# Altium schematic sheet Stepper_Driver.SchDoc
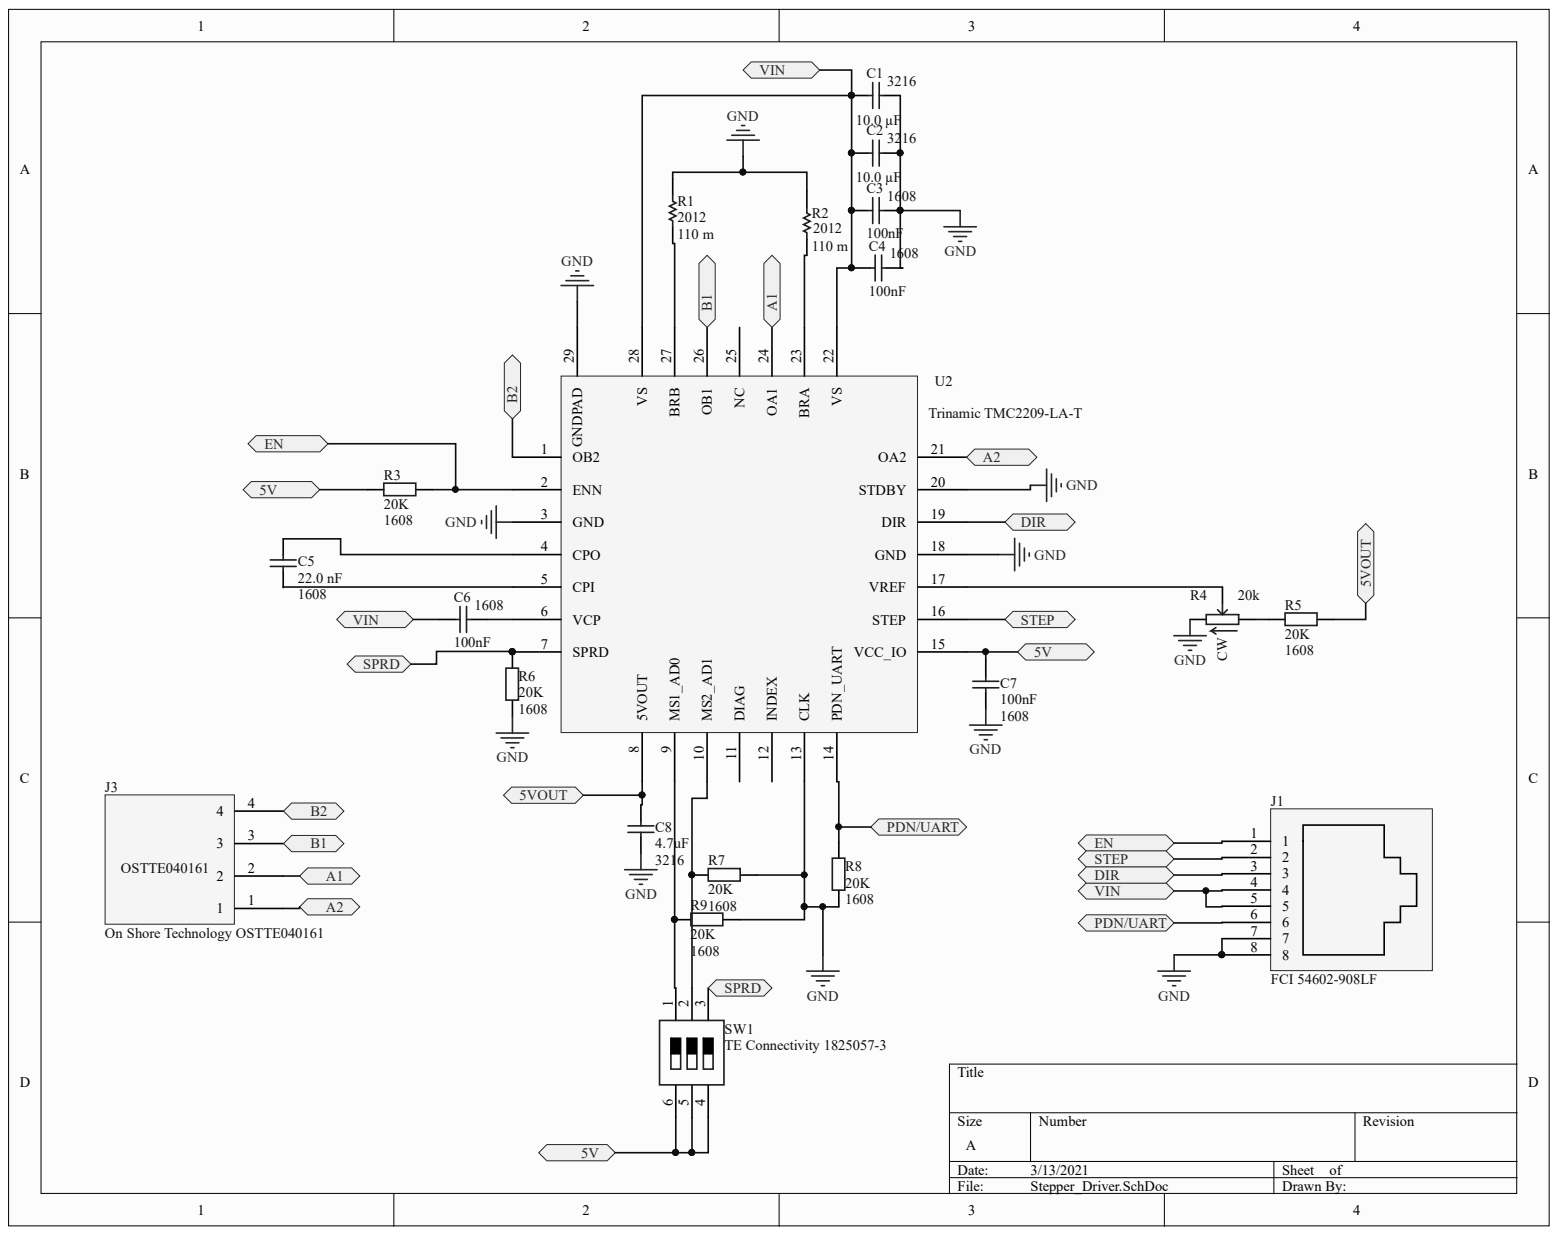
[diagram p0: Stepper_Driver.SchDoc]

## Parts
C1  Size: 3216  [High Density]  (hidden comment: Cap)
C2  Capacitance: 10.0 µF  Size: 3216  [High Density]  (hidden comment: Cap)
C3  100nF  Size: 1608  [CAPC1608X09N]
C4  100nF  Size: 1608  [CAPC1608X09N]
C5  Size: 1608  [PCB-v3fthkug2pbv1qvbzexa-1]  (hidden comment: Walsin Technologies 0603B223K500CT)
C6  100nF  Size: 1608  [CAPC1608X09N]
C7  100nF  Size: 1608  [CAPC1608X09N]
C8  4.7uF  Size: 3216  [CAPC3216X180X55ML20T25]
J1  FCI 54602-908LF  Manufacturer Part Number: 54602-908LF  [PCB-kyusfhn5h9rxu8er00w0-1]
J3  On Shore Technology OSTTE040161  [OSTTE040161]
R1  Case/Package: 2012  [RESC2012X06N]  (hidden comment: Panasonic ERJ-6DSFR11V)
R2  Case/Package: 2012  [RESC2012X06N]  (hidden comment: Panasonic ERJ-6DSFR11V)
R3  20K  Size: 1608  [PCB-1ci9j4ma2hnf2m9akcl3-1]
R4  Resistance: 20k  [PCB-aorwn4mtqwimg0tyvqvx-1]  (hidden comment: TC33X-2-203E)
R5  20K  Size: 1608  [PCB-1ci9j4ma2hnf2m9akcl3-1]
R6  20K  Size: 1608  [PCB-1ci9j4ma2hnf2m9akcl3-1]
R7  20K  [PCB-1ci9j4ma2hnf2m9akcl3-1]
R8  20K  Size: 1608  [PCB-1ci9j4ma2hnf2m9akcl3-1]
R9  20K  Size: 1608  [PCB-1ci9j4ma2hnf2m9akcl3-1]
SW1  TE Connectivity 1825057-3  [PCB-chfgz6m5i36qrmmne85a-1]
U2  Trinamic TMC2209-LA-T  [QFN50P500X500X85_HS-29N]

## Ports
5V, 5VOUT, A1, A2, B1, B2, DIR, EN, PDN/UART, SPRD, STEP, VIN

## Nets
GND: C1.2 C2.2 C3.2 C4.2 C7.1 C8.1 J1.7 J1.8 R1.2 R2.2 R4.3 R6.2 R7.1 R8.1 R9.1 U2.3(GND) U2.13(CLK) U2.18(GND) U2.20(STDBY) U2.29(GNDPAD)
5V: C7.2 R3.2 SW1.4 SW1.5 SW1.6 U2.15(VCC_IO) ; port 5V
5VOUT: C8.2 R5.1 U2.8(5VOUT) ; port 5VOUT
A1: J3.2 U2.24(OA1) ; port A1
A2: J3.1 U2.21(OA2) ; port A2
B1: J3.3 U2.26(OB1) ; port B1
B2: J3.4 U2.1(OB2) ; port B2
DIR: J1.3 U2.19(DIR) ; port DIR
EN: J1.1 R3.1 U2.2(ENN) ; port EN
PDN/UART: J1.6 R8.2 U2.14(PDN_UART) ; port PDN/UART
SPRD: R6.1 SW1.3 U2.7(SPRD) ; port SPRD
STEP: J1.2 U2.16(STEP) ; port STEP
VIN: C1.1 C2.1 C3.1 C4.1 C6.1 J1.4 J1.5 U2.22(VS) U2.28(VS) ; port VIN
NetC5_1: C5.1 U2.5(CPI)
NetC5_2: C5.2 U2.4(CPO)
NetC6_2: C6.2 U2.6(VCP)
NetR1_1: R1.1 U2.27(BRB)
NetR2_1: R2.1 U2.23(BRA)
NetR4_1: R4.1 R5.2
NetR4_2: R4.2 U2.17(VREF)
NetR7_2: R7.2 SW1.2 U2.10(MS2_AD1)
NetR9_2: R9.2 SW1.1 U2.9(MS1_AD0)

Not connected: U2 11(DIAG) 12(INDEX) 25(NC)

## Library data of the parts
C1 = CMP-bac072e4006c6507-6: CL31 Series 10 uF 50 V ±10 % Tolerance X5R SMT Multilayer Ceramic Capacitor; Manufacturer Part Number: CL31A106KBHNNNE; Capacitance: 10.0 µF; Lead-Free Status: Lead Free; Voltage Rating (DC): 50.0 V; Component Kind: Standard; Library Name: CommunityVault; Mounting Style: Surface Mount; OctopartID: bac072e4006c6507
C2 = CMP-bac072e4006c6507-6: CL31 Series 10 uF 50 V ±10 % Tolerance X5R SMT Multilayer Ceramic Capacitor; Manufacturer Part Number: CL31A106KBHNNNE; Lead-Free Status: Lead Free; Voltage Rating (DC): 50.0 V; Component Kind: Standard; Library Name: CommunityVault; Mounting Style: Surface Mount; OctopartID: bac072e4006c6507
C3 C4 C6 C7 = CMP-7555619-5: CL10 Series 0.1 uF 50 V ±5 % Tolerance X7R SMT Multilayer Ceramic Capacitor; Manufacturer Part Number: CL10B104JB8NNNC; Component Kind: Standard; Library Name: CommunityVault; Mounting Style: Surface Mount
C5 = CMP-f9383295d20126ff-1: Multilayer Ceramic Capacitor, 0.022 F, 10%, X7R, 50 V, 0603 [1608 Metric]; Capacitance: 22.0 nF; Voltage Rating (DC): 50.0 V; Capacitance Tolerance: ±10%; Component Kind: Standard; Dielectric Characteristic: X7R; Library Name: CommunityVault; Manufacturer Part Number: 0603B223K500CT; OctopartID: f9383295d20126ff; Octopart URL: http://octopart.com/0603b223k500ct-walsin+technologies-44502623; Packaging: Cut Tape (CT); RoHS: Compliant
C8 = CMP-7356bb72f6b9ac91-2: CL31 Series 4.7 uF 50 V ±10 % Tolerance X7R SMT Multilayer Ceramic Capacitor; Manufacturer Part Number: CL31B475KBHNNNE; Component Kind: Standard; Dielectric Characteristic: X7R; Library Name: CommunityVault; OctopartID: 7356bb72f6b9ac91; Octopart URL: http://octopart.com/cl31b475kbhnnne-samsung-19832969; RoHS: Compliant
J1 = CMP-1a15d1442034c5d2-8: Conn Modular Jack F 8 POS 2.54mm Solder RA Thru-Hole 8 Terminal 1 Port Box Cat 3; Gender: Male; Lead-Free Status: Lead Free; Number of Contacts: 8; Color: Black; Component Kind: Standard; Contact Material: Phosphor Bronze; Contact Plating: Gold; Library Name: CommunityVault; Mounting Style: Through Hole, PCB; OctopartID: 1a15d1442034c5d2; Octopart URL: http://octopart.com/54602-908lf-fci-39526215; REACH SVHC Compliance: No SVHC; RoHS: Compliant; Size-Height: 12.7 mm; Size-Length: 15.3 mm; Size-Width: 17.7 mm
J3 = CMP-b52b7c252a8f6aec-1: Connector Terminal Block 4 Position..138" /3.5Mm Space Th Top Screw; Number of Positions: 4; Pin Pitch: 3.50 mm; Component Kind: Standard; Housing Material: Nylon; Library Name: CommunityVault; Octopart URL: http://octopart.com/ostte040161-on+shore+technology-309954; Size-Width: 7.00 mm
R1 R2 = CMP-dbb116dd11c00476-1: Res Thick Film 0805 110m Ohm 0.5% 1/2W ±150ppm/°C Molded SMD T/R; Power Rating: 500 mW; Resistance Tolerance: ±1%; Component Kind: Standard; Library Name: CommunityVault; Lifecycle Status: Not Listed by Manufacturer; Manufacturer Part Number: ERJ-6DSFR11V; OctopartID: dbb116dd11c00476; Octopart URL: https://octopart.com/erj-6dsfr11v-panasonic-73854743; Resistance: 110 m; RoHS: Compliant
R3 R5-R9 = CMP-aa1d6305ca5475da-8: Res Thick Film 1.6 x 0.8 mm 20K Ohm 1% 0.1W(1/10W) 100ppm/ C Molded SMD Paper T/R; Manufacturer Part Number: RC0603FR-0720KL; Lead-Free Status: Lead Free; Power Rating: 100 mW; Voltage Rating (DC): 50.0 V; Component Kind: Standard; Library Name: CommunityVault; Mounting Style: Surface Mount; Number of Pins: 2; Packaging: Tape & Reel; Resistance Tolerance: ±1%; RoHS: Compliant; Size-Height: 450 µm; Size-Length: 1.60 mm
  R7: Size: 1608
R4 = CMP-3ffbf6f0cda3e03c-5: Res Trimmer 20K Ohm 25% 1/10W 1(Elec)/1(Mech)Turn (3 X 3.8mm) Foot Print SMD Embossed T/R; Power Rating: 100 mW; Temperature Coefficient: -250 ppm/°C to 250 ppm/°C; Component Kind: Standard; Library Name: CommunityVault; Manufacturer Part Number: TC33X-2-203E; Mounting Style: Surface Mount; OctopartID: 3ffbf6f0cda3e03c; Octopart URL: https://octopart.com/tc33x-2-203e-bourns-120638; Operating Temperature: 120 °C (max); Packaging: Cut Tape (CT), Tape & Reel (TR); REACH SVHC Compliance: No SVHC; Resistance Tolerance: ±25%; RoHS: Compliant; Size-Height: 1.19 mm; Size-Length: 3.00 mm
SW1 = CMP-3f99f6ac8d38e05e-1: Switch DIP ON OFF SPST 3 Flush Slide 0.1A 20VDC Gull Wing 2000Cycles 2.54mm SMD T/R; Component Kind: Standard; Library Name: CommunityVault
U2 = CMP-333afc2d4935ecfd-4: Motor Driver, Stepper, QFN-28; Component Kind: Standard; Library Name: CommunityVault
